# Altium schematic sheet power.SchDoc
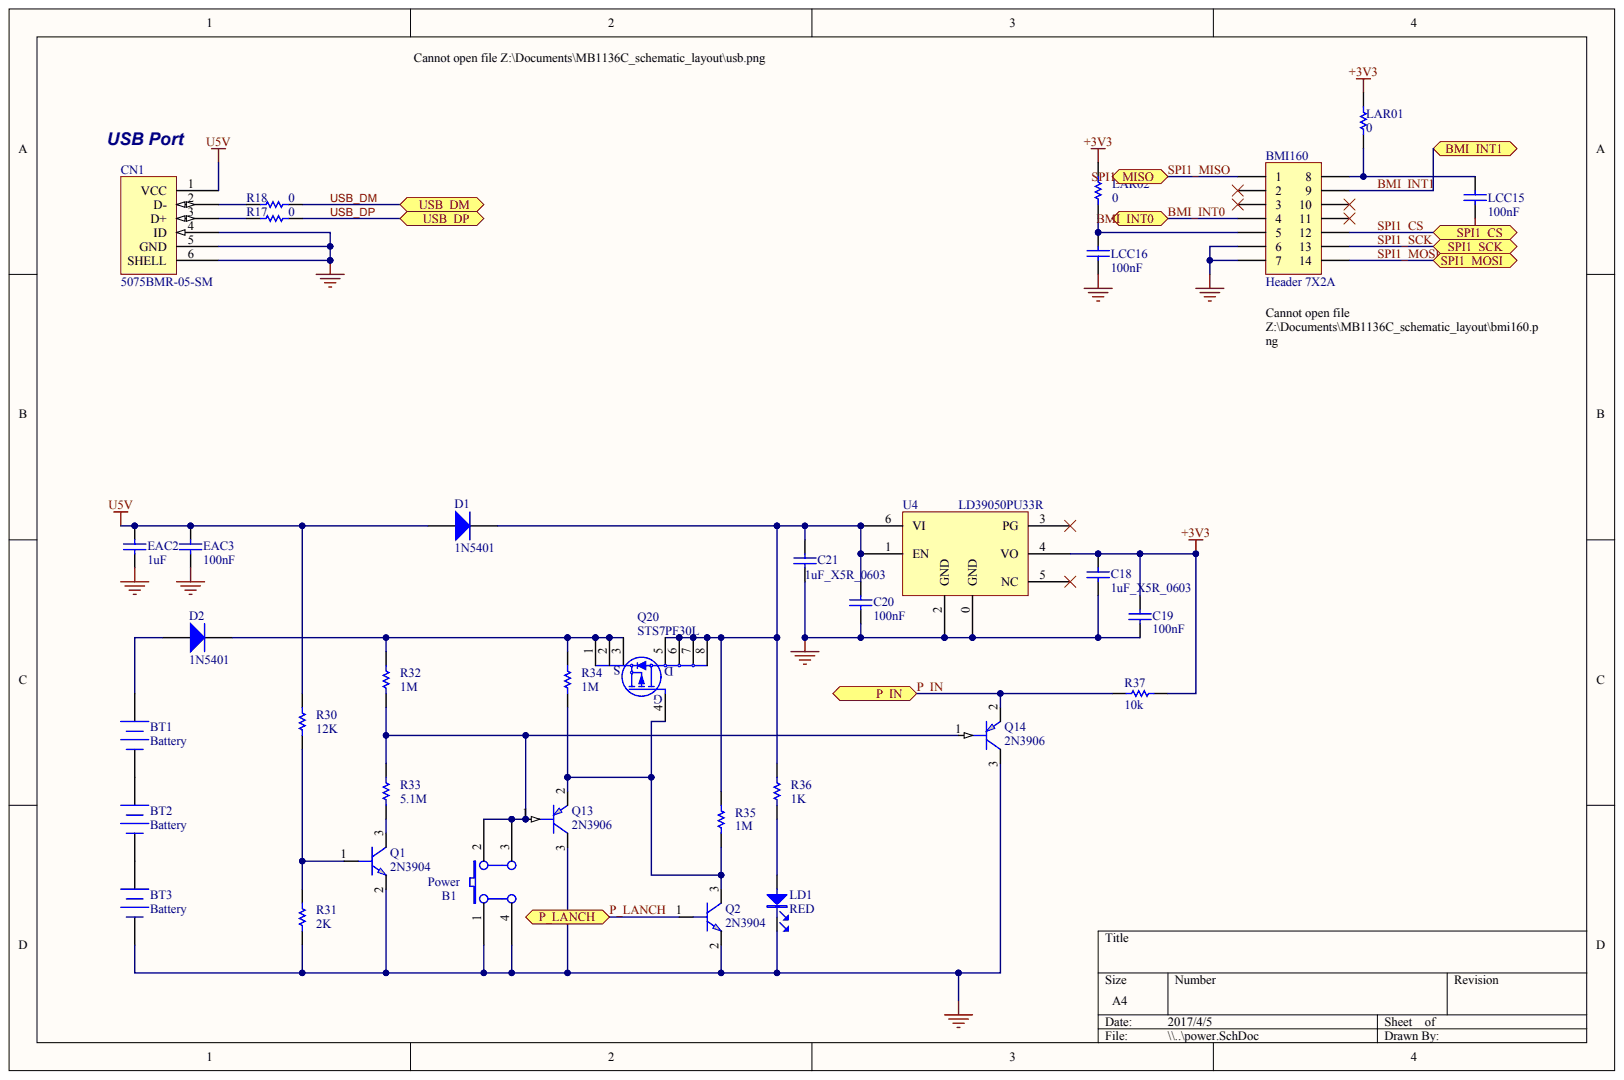
[diagram p0: power.SchDoc]

## Parts
B1  Power
BMI160  Header 7X2A
BT1  Battery
BT2  Battery
BT3  Battery
C18  1uF_X5R_0603
C19  100nF
C20  100nF
C21  1uF_X5R_0603
CN1  5075BMR-05-SM
D1  1N5401
D2  1N5401
EAC2  1uF
EAC3  100nF
LAR01  0
LAR02  0
LCC15  100nF
LCC16  100nF
LD1  RED
Q1  2N3904
Q2  2N3904
Q13  2N3906
Q14  2N3906
Q20  STS7PF30L
R17  0
R18  0
R30  12K
R31  2K
R32  1M
R33  5.1M
R34  1M
R35  1M
R36  1K
R37  10k
U4  LD39050PU33R

## Ports
BMI_INT0, BMI_INT1, P_IN, P_LANCH, SPI1_CS, SPI1_MISO, SPI1_MOSI, SPI1_SCK, USB_DM, USB_DP

## Nets
+3V3: C18.1 C19.2 LAR01.2 LAR02.2 R37.1 U4.4(VO)
GND: B1.1 B1.4 BMI160.6 BMI160.7 BT3.2 C18.2 C19.1 C20.1 C21.2 CN1.4(ID) CN1.5(GND) CN1.6(SHELL) EAC2.2 EAC3.2 LCC15.1 LCC16.1 LD1.2 Q1.2 Q2.2 Q13.3 Q14.3 R31.1 U4.0(GND) U4.2(GND)
U5V: CN1.1(VCC) D1.1 EAC2.1 EAC3.1 R30.2
BMI_INT0: BMI160.4 ; port BMI_INT0
BMI_INT1: BMI160.9 ; port BMI_INT1
P_IN: Q14.2 R37.2 ; port P_IN
P_LANCH: Q2.1 ; port P_LANCH
SPI1_CS: BMI160.12 ; port SPI1_CS
SPI1_MISO: BMI160.1 ; port SPI1_MISO
SPI1_MOSI: BMI160.14 ; port SPI1_MOSI
SPI1_SCK: BMI160.13 ; port SPI1_SCK
USB_DM: R18.2 ; port USB_DM
USB_DP: R17.2 ; port USB_DP
NetB1_2: B1.2 B1.3 Q13.1 Q14.1 R32.1 R33.2
NetBMI160_5: BMI160.5 LAR02.1 LCC16.2
NetBMI160_8: BMI160.8 LAR01.1 LCC15.2
NetBT1_1: BT1.1 D2.1
NetBT1_2: BT1.2 BT2.1
NetBT2_2: BT2.2 BT3.1
NetC20_2: C20.2 C21.1 D1.2 Q20.5 Q20.6 Q20.7 Q20.8 R35.2 R36.2 U4.1(EN) U4.6(VI)
NetCN1_2: CN1.2(D-) R18.1
NetCN1_3: CN1.3(D+) R17.1
NetD2_2: D2.2 Q20.1 Q20.2 Q20.3 R32.2 R34.2
NetLD1_1: LD1.1 R36.1
NetQ1_1: Q1.1 R30.1 R31.2
NetQ1_3: Q1.3 R33.1
NetQ2_3: Q2.3 Q13.2 Q20.4 R34.1 R35.1

Not connected: BMI160 2 3 10 11; U4 3(PG) 5(NC)

## Library data of the parts
C18 C21 = (no symbol name): Value: 1µF; Rated voltage: 25V; Tolerance: 10%; Manufacturer: *; Manufacturer P/N: *; Order code Farnell: 1288202RL; Power: *; Technology: X5R; Temperature  Factor: *; Temperature Max: +125°C; Temperature Min: -55°C; STS Code: *
D1 D2 = (no symbol name): Manuf_1: STM; Provided: ST; Order: BAT60JFILM; ECCN_US/EU: EAR99/NEC; Revision: July-2002: Re-released for DXP Platform.; Manuf_Part_1: BAT60JFILM; Distrib: ST
EAC2 EAC3 LCC15 LCC16 = (no symbol name): Value: 100pF; Published: 8-Jun-2000; Revision: July-2002: Re-released for DXP Platform.; Publisher: Altium Limited
LAR01 LAR02 = (no symbol name): Value: 1K; Published: 8-Jun-2000; Revision: July-2002: Re-released for DXP Platform.; Publisher: Altium Limited
Q1 Q2 Q13 Q14 = (no symbol name): Text Field1: 20; Text Field2: 20
R17 R18 R30-R36 = (no symbol name): Value: 10K
B1 BMI160 BT1-BT3 C19 C20 CN1 LD1 Q20 R37 U4 = (no symbol name)
  BMI160: LatestRevisionDate: 17-Jul-2002; LatestRevisionNote: Re-released for DXP Platform.
  BT1-BT3: Published: 24-Mar-1999; LatestRevisionDate: 17-Jul-2002; LatestRevisionNote: Re-released for DXP Platform.; PackageReference: BAT-2; PackageDescription: Battery; 2 Leads
  C19 C20: Revision: July-2002: Re-released for DXP Platform.; Value: 100nF
  CN1: Manuf_Part_1: 5075BMR-05-SM; Order: 5075BMR-05-SM; ECCN: ????
  R37: Value: 10K
  BMI160 BT1-BT3: Publisher: Altium Limited
